# Altium schematic sheet [PL-CRF-01]Sensor_V2.0.SchDoc
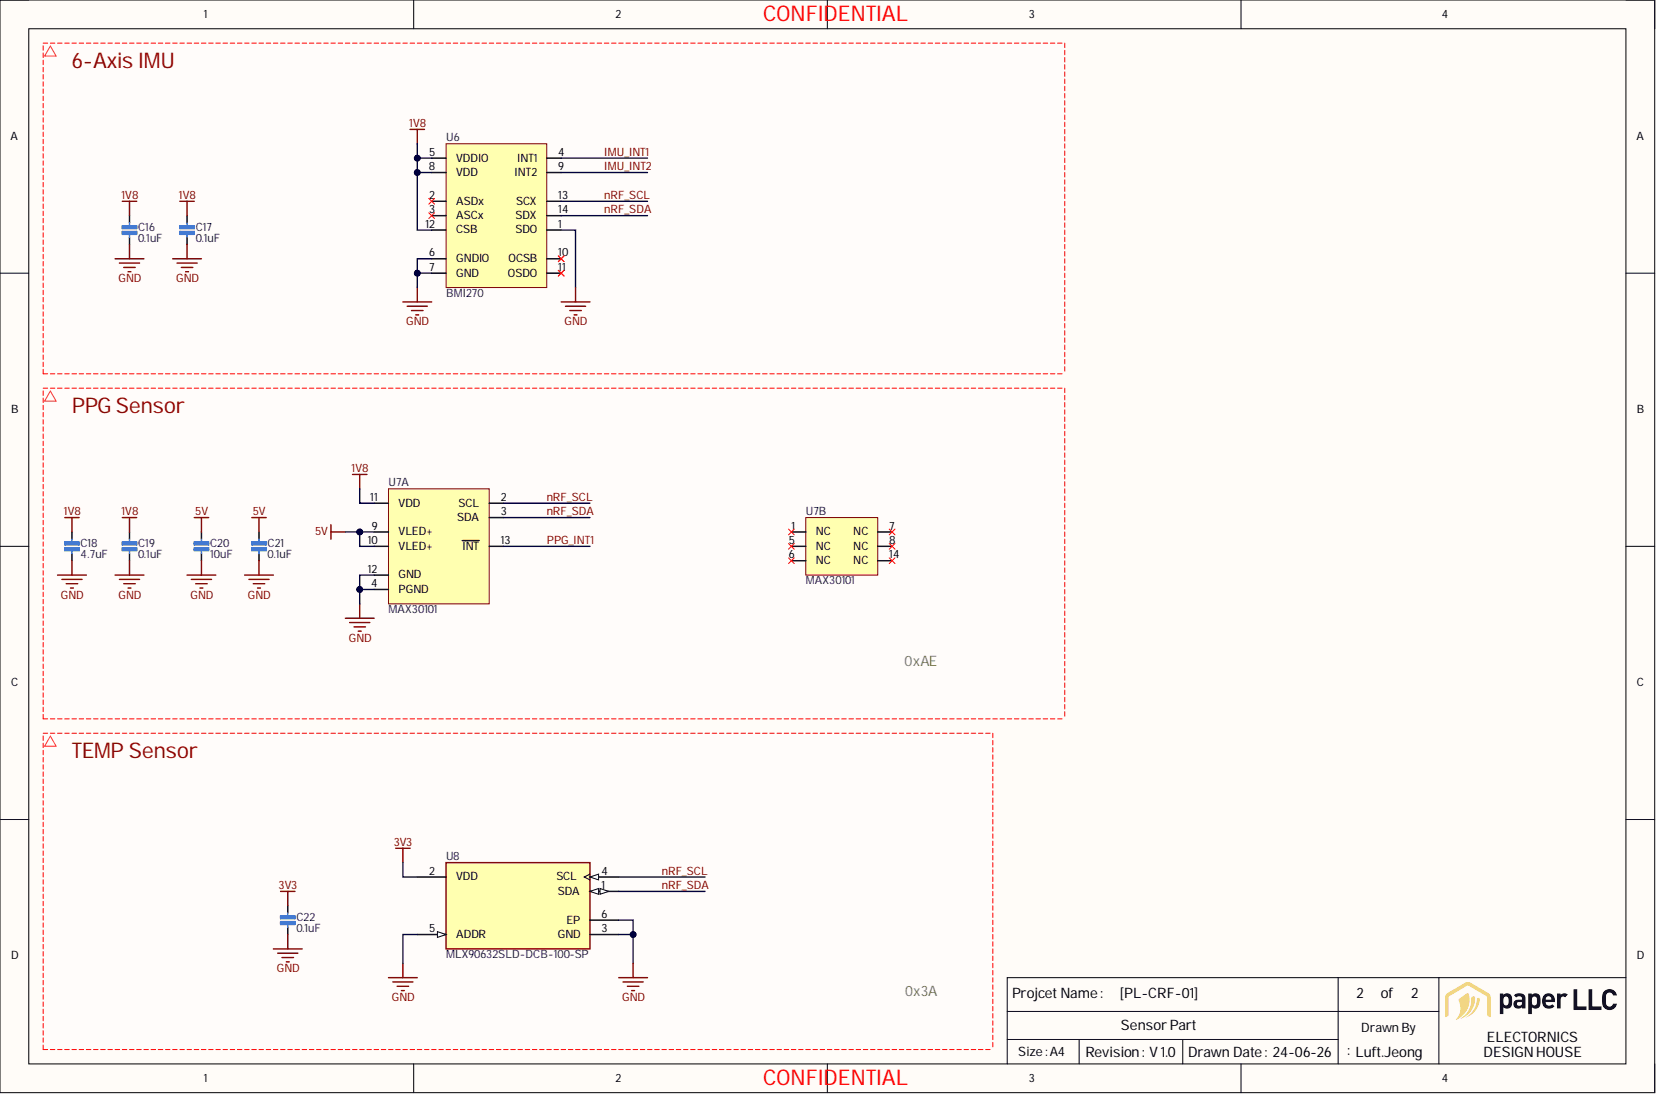
[diagram p0: [PL-CRF-01]Sensor_V2.0.SchDoc]

## Parts
C16  0.1uF  [CAPC1005X55N_2]
C17  0.1uF  [CAPC1005X55N_2]
C18  4.7uF  [CAPC1005X55N_2]
C19  0.1uF  [CAPC1005X55N_2]
C20  10uF  [CAPC1005X55N_2]
C21  0.1uF  [CAPC1005X55N_2]
C22  0.1uF  [CAPC1005X55N_2]
U6  BMI270  [XDCR_BMI270]
U7  MAX30101  [FP-F143A5-1-MFG]  (parts A,B)
U8  MLX90632SLD-DCB-100-SP  [FP-MLX90632SLD-BCB-000-SP-MFG]

## Nets
1V8: C16.1 C17.1 C18.1 C19.1 U6.5(VDDIO) U6.8(VDD) U6.12(CSB) U7.11(VDD)
3V3: C22.1 U8.2(VDD)
5V: C20.1 C21.1 U7.9(VLED+) U7.10(VLED+)
GND: C16.2 C17.2 C18.2 C19.2 C20.2 C21.2 C22.2 U6.1(SDO) U6.6(GNDIO) U6.7(GND) U7.4(PGND) U7.12(GND) U8.3(GND) U8.5(ADDR) U8.6(EP)
IMU_INT1: U6.4(INT1)
IMU_INT2: U6.9(INT2)
nRF_SCL: U6.13(SCX) U7.2(SCL) U8.4(SCL)
nRF_SDA: U6.14(SDX) U7.3(SDA) U8.1(SDA)
PPG_INT1: U7.13(INT)

Not connected: U6 2(ASDx) 3(ASCx) 10(OCSB) 11(OSDO); U7 1(NC) 5(NC) 6(NC) 7(NC) 8(NC) 14(NC)

## Library data of the parts
C16-C22 = CAP_MLCC: Ceramic MLCC CAP; Manufacture: Murata; Dielectric: X5R; Volage Rating: 50V; Component Kind: Standard; Library Name: PAPER_Basic_Lib.SchLib
  C16 C17 C19 C21 C22: Value: 0.1uF
  C18: Value: 4.7uF
  C20: Value: 10uF
U6 = BMI270: 6-axis, smart, low power Inertial Measurement Unit for high-performance applications; Component Kind: Standard; Library Name: CRF.SchLib
U7 = MAX30101: High-Sensitivity Pulse Oximeter and  Heart-Rate Sensor for Wearable Health; Component Kind: Standard; Library Name: CRF.SchLib
U8 = CMP-116302-000004-1: SENSOR DGTL -20C-85C 5SFN; Output Type: I2C; Sensing Method: Infrared (IR); Component Kind: Standard; Library Name: Altium Content Vault; Max Operating Temperature: 85°C; Min Operating Temperature: -20°C; Mount: Surface Mount; Packaging: Bag; RoHS Compliant: Yes
